# Altium schematic sheet 2.Control_Unit.SchDoc(2.Control_Unit)
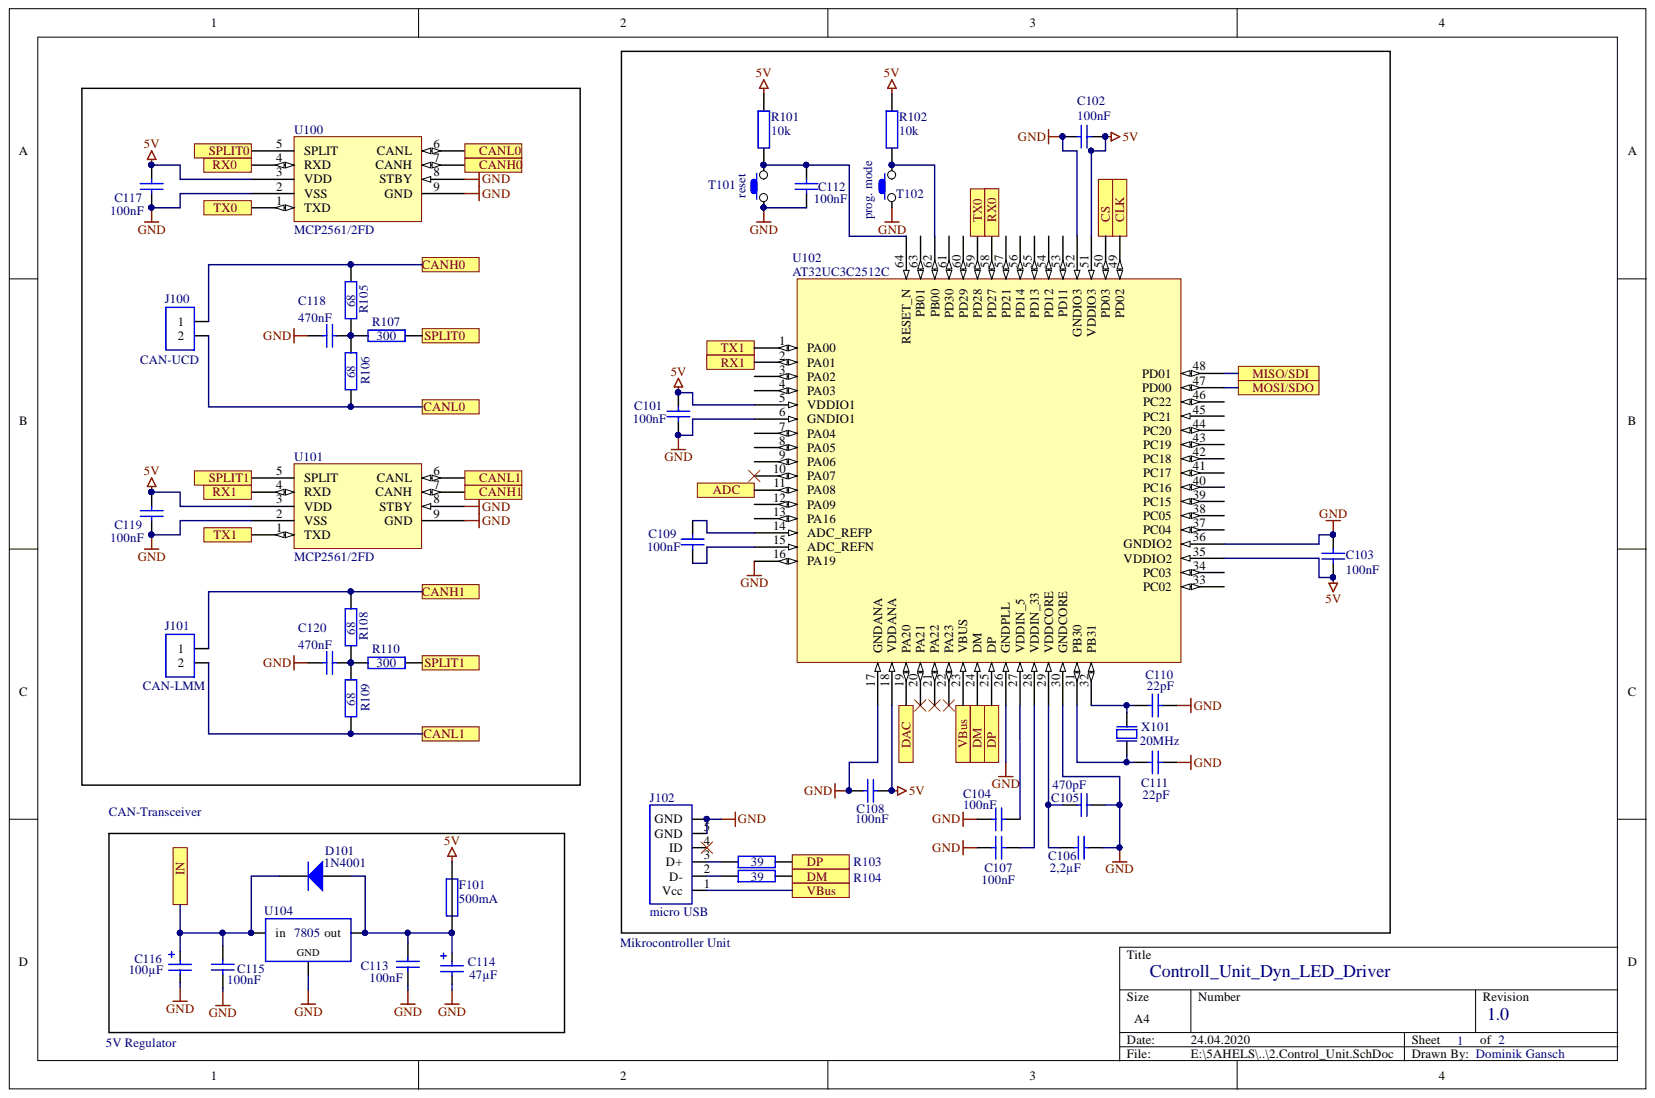
[diagram p0: 2.Control_Unit.SchDoc]

## Parts
C101  value 100nF  [1206]  (hidden comment: Cap Semi)
C102  100nF  [1206]
C103  value 100nF  [1206]  (hidden comment: Cap Semi)
C104  value 100nF  [1206]  (hidden comment: Cap Semi)
C105  value 470pF  [0805]  (hidden comment: Cap Semi)
C106  value 2,2µF  [0805]  (hidden comment: Cap Semi)
C107  value 100nF  [1206]  (hidden comment: Cap Semi)
C108  value 100nF  [1206]  (hidden comment: Cap Semi)
C109  value 100nF  [1206]  (hidden comment: Cap Semi)
C110  value 22pF  [1206]  (hidden comment: Cap Semi)
C111  value 22pF  [1206]  (hidden comment: Cap Semi)
C112  value 100nF  [1206]  (hidden comment: Cap Semi)
C113  value 100nF  [0805]  (hidden comment: Cap Semi)
C114  47µF  [C7545]
C115  value 100nF  [0805]  (hidden comment: Cap Semi)
C116  100µF  [C7545]
C117  value 100nF  [1206]  (hidden comment: Cap Semi)
C118  value 470nF  [0603]  (hidden comment: Cap Semi)
C119  value 100nF  [1206]  (hidden comment: Cap Semi)
C120  value 470nF  [0603]  (hidden comment: Cap Semi)
D101  1N4001  [SOD-80]
F101  500mA  [PolyFuse-500mA]
J100  CAN-UCD  [SIP-2]
J101  CAN-LMM  [SIP-2]
J102  micro USB  [Micro_USB]
R101  10k  [0805]
R102  10k  [0805]
R103  39  [0805]
R104  39  [0805]
R105  68  [0805]
R106  68  [0805]
R107  300  [0805]
R108  68  [0805]
R109  68  [0805]
R110  300  [0805]
T101  reset  [Tast]
T102  prog. mode  [Tast]
U100  MCP2561/2FD  [SON65P290X90_HS-9M]
U101  MCP2561/2FD  [SON65P290X90_HS-9M]
U102  AT32UC3C2512C  [QFN50P900X900X100_HS-65M]
U104  7805  [TO-220H]
X101  20MHz  [SMD_QUARZ]

## Ports
ADC, CANH0, CANH1, CANL0, CANL1, CLK, CS, DAC, DM, DP, IN, MISO/SDI, MOSI/SDO, RX0, RX1, SPLIT0, SPLIT1, TX0, TX1, VBus

## Nets
5V: C101.2 C102.2 C103.1 C108.2 C117.2 C119.2 F101.2 R101.2 R102.2 U100.3(VDD) U101.3(VDD) U102.5(VDDIO1) U102.18(VDDANA) U102.35(VDDIO2) U102.51(VDDIO3)
GND: C101.1 C102.1 C103.2 C104.1 C105.2 C106.2 C107.1 C108.1 C110.1 C111.1 C112.1 C113.1 C114.2 C115.1 C116.2 C117.1 C118.2 C119.1 C120.2 J102.5(GND) J102.GND T101.1 T102.1 U100.2(VSS) U100.8(STBY) U100.9(GND) U101.2(VSS) U101.8(STBY) U101.9(GND) U102.6(GNDIO1) U102.17(GNDANA) U102.26(GNDPLL) U102.30(GNDCORE) U102.36(GNDIO2) U104.3
ADC: U102.11(PA08) ; port ADC
CANH0: J100.1 R105.2 U100.7(CANH) ; port CANH0
CANH1: J101.1 R108.2 U101.7(CANH) ; port CANH1
CANL0: J100.2 R106.1 U100.6(CANL) ; port CANL0
CANL1: J101.2 R109.1 U101.6(CANL) ; port CANL1
CLK: U102.49(PD02) ; port CLK
CS: U102.50(PD03) ; port CS
DAC: U102.19(PA20) ; port DAC
DM: R104.1 U102.24(DM) ; port DM
DP: R103.1 U102.25(DP) ; port DP
IN: C115.2 C116.1 D101.2 U104.1 ; port IN
MISO/SDI: U102.48(PD01) ; port MISO/SDI
MOSI/SDO: U102.47(PD00) ; port MOSI/SDO
RX0: U100.4(RXD) U102.58(PD27) ; port RX0
RX1: U101.4(RXD) U102.2(PA01) ; port RX1
SPLIT0: R107.2 U100.5(SPLIT) ; port SPLIT0
SPLIT1: R110.2 U101.5(SPLIT) ; port SPLIT1
TX0: U100.1(TXD) U102.59(PD28) ; port TX0
TX1: U101.1(TXD) U102.1(PA00) ; port TX1
VBus: J102.1(Vcc) U102.23(VBUS) ; port VBus
NetC104_2: C104.2
NetC105_1: C105.1 C106.1 U102.29(VDDCORE)
NetC107_2: C107.2 U102.28(VDDIN_33)
NetC109_1: C109.1 U102.15(ADC_REFN)
NetC109_2: C109.2 U102.14(ADC_REFP)
NetC110_2: C110.2 U102.32(PB31) X101.2
NetC111_2: C111.2 U102.31(PB30) X101.1
NetC112_2: C112.2 R101.1 T101.2 U102.64(RESET_N)
NetC113_2: C113.2 C114.1 D101.1 F101.1 U104.2
NetC118_1: C118.1 R105.1 R106.2 R107.1
NetC120_1: C120.1 R108.1 R109.2 R110.1
NetJ102_2: J102.2(D-) R104.2
NetJ102_3: J102.3(D+) R103.2
NetR102_1: R102.1 T102.2

Not connected: J102 4(ID); U102 3(PA02) 4(PA03) 7(PA04) 8(PA05) 9(PA06) 10(PA07) 12(PA09) 13(PA16) 16(PA19) 20(PA21) 21(PA22) 22(PA23) 27(VDDIN_5) 33(PC02) 34(PC03) 37(PC04) 38(PC05) 39(PC15) 40(PC16) 41(PC17) 42(PC18) 43(PC19) 44(PC20) 45(PC21) 46(PC22) 52(GNDIO3) 53(PD11) 54(PD12) 55(PD13) 56(PD14) 57(PD21) 60(PD29) 61(PD30) 62(PB00) 63(PB01)

## Library data of the parts
C101 C103-C113 C115 C117-C120 = Capacitor: Capacitor (Semiconductor SIM Model); Simulation: CAP; Signal Integrity: Cap Semi; Published: 8-Jun-2000; PackageReference: C1206; PackageDescription: Chip Capacitor; Library Name: 6.Dynamic_LED_Driver.SchLib; LatestRevisionNote: IPC-7351 Footprint Added.; LatestRevisionDate: 29-May-2009; Component Kind: Standard; Publisher: Altium Limited
C102 = KO: Kondensator; Simulation: Model Name; Library Name: Dynamic_LED_Driver.IntLib; Component Kind: Standard
C114 C116 = ELKO: Elko, Tantal; Simulation: Model Name; Library Name: 6.Dynamic_LED_Driver.SchLib; Component Kind: Standard
D101 = Diode: Default Diode; Simulation: DIODE; Signal Integrity: Diode; Published: 8-Jun-2000; PackageVersion: Sep-1996; PackageReference: SMC; PackageDescription: DO-214-AB/SMC; 2 C-Bend Leads; Body 7.9 x 5.9 mm, inc. leads (LxW); Library Name: 6.Dynamic_LED_Driver.SchLib; LatestRevisionNote: Re-released for DXP Platform.; LatestRevisionDate: 17-Jul-2002; Component Kind: Standard; Code_JEDEC: DO-214-AB; Publisher: Altium Limited
F101 = Fuse: Component Kind: Standard; Library Name: Gansch-Sym.SchLib
J100 J101 = CON2: Stecker; Library Name: Dynamic_LED_Driver.IntLib; Component Kind: Standard
J102 = USB 2.0: Stecker; Component Kind: Standard; Library Name: Gansch-Sym.SchLib
R101-R110 = RES: Component Kind: Standard
  R101 R102 R105-R110: Library Name: 6.Dynamic_LED_Driver.SchLib
  R103 R104: Library Name: Gansch-Sym.SchLib
T101 = Taster: Library Name: HTL Lib.SchLib; Description: Taster; Component Kind: Standard
T102 = Taster: Is used to initiate the usb-programming mode; Library Name: HTL Lib.SchLib; Component Kind: Standard
U104 = 78xx: IC; Component Kind: Standard; Library Name: Gansch-Sym.SchLib
X101 = QUARZ: Library Name: 6.Dynamic_LED_Driver.SchLib; Component Kind: Standard
U100 U101 = MCP2561/2FD: Library Name: LED_Driver_Library.SchLib; Component Kind: Standard
U102 = AT32UC3C2512C: Library Name: 6.Dynamic_LED_Driver.SchLib; Component Kind: Standard
